# Altium schematic sheet iMX6SL_Power.SchDoc
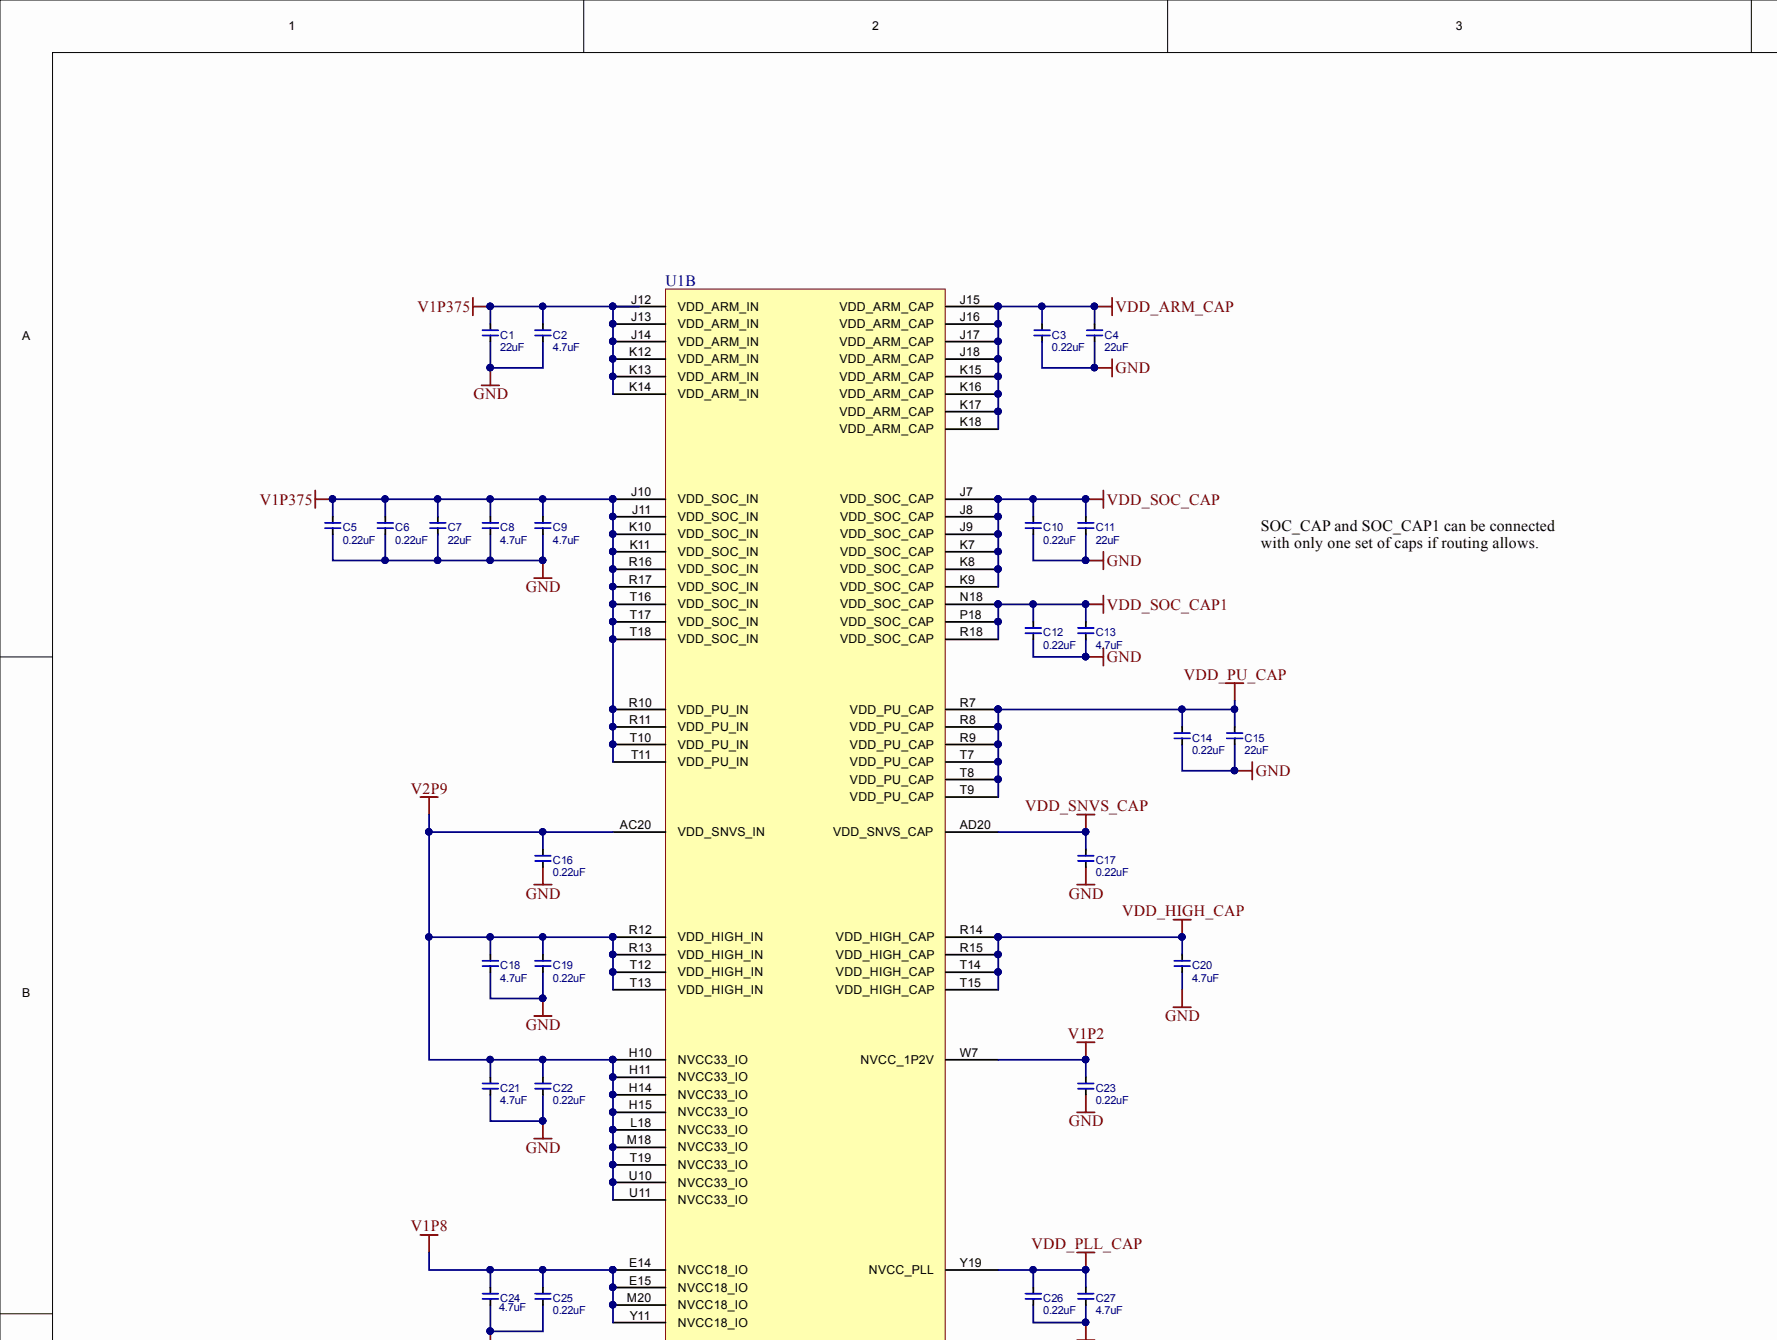
[diagram p0: iMX6SL_Power.SchDoc, top left]
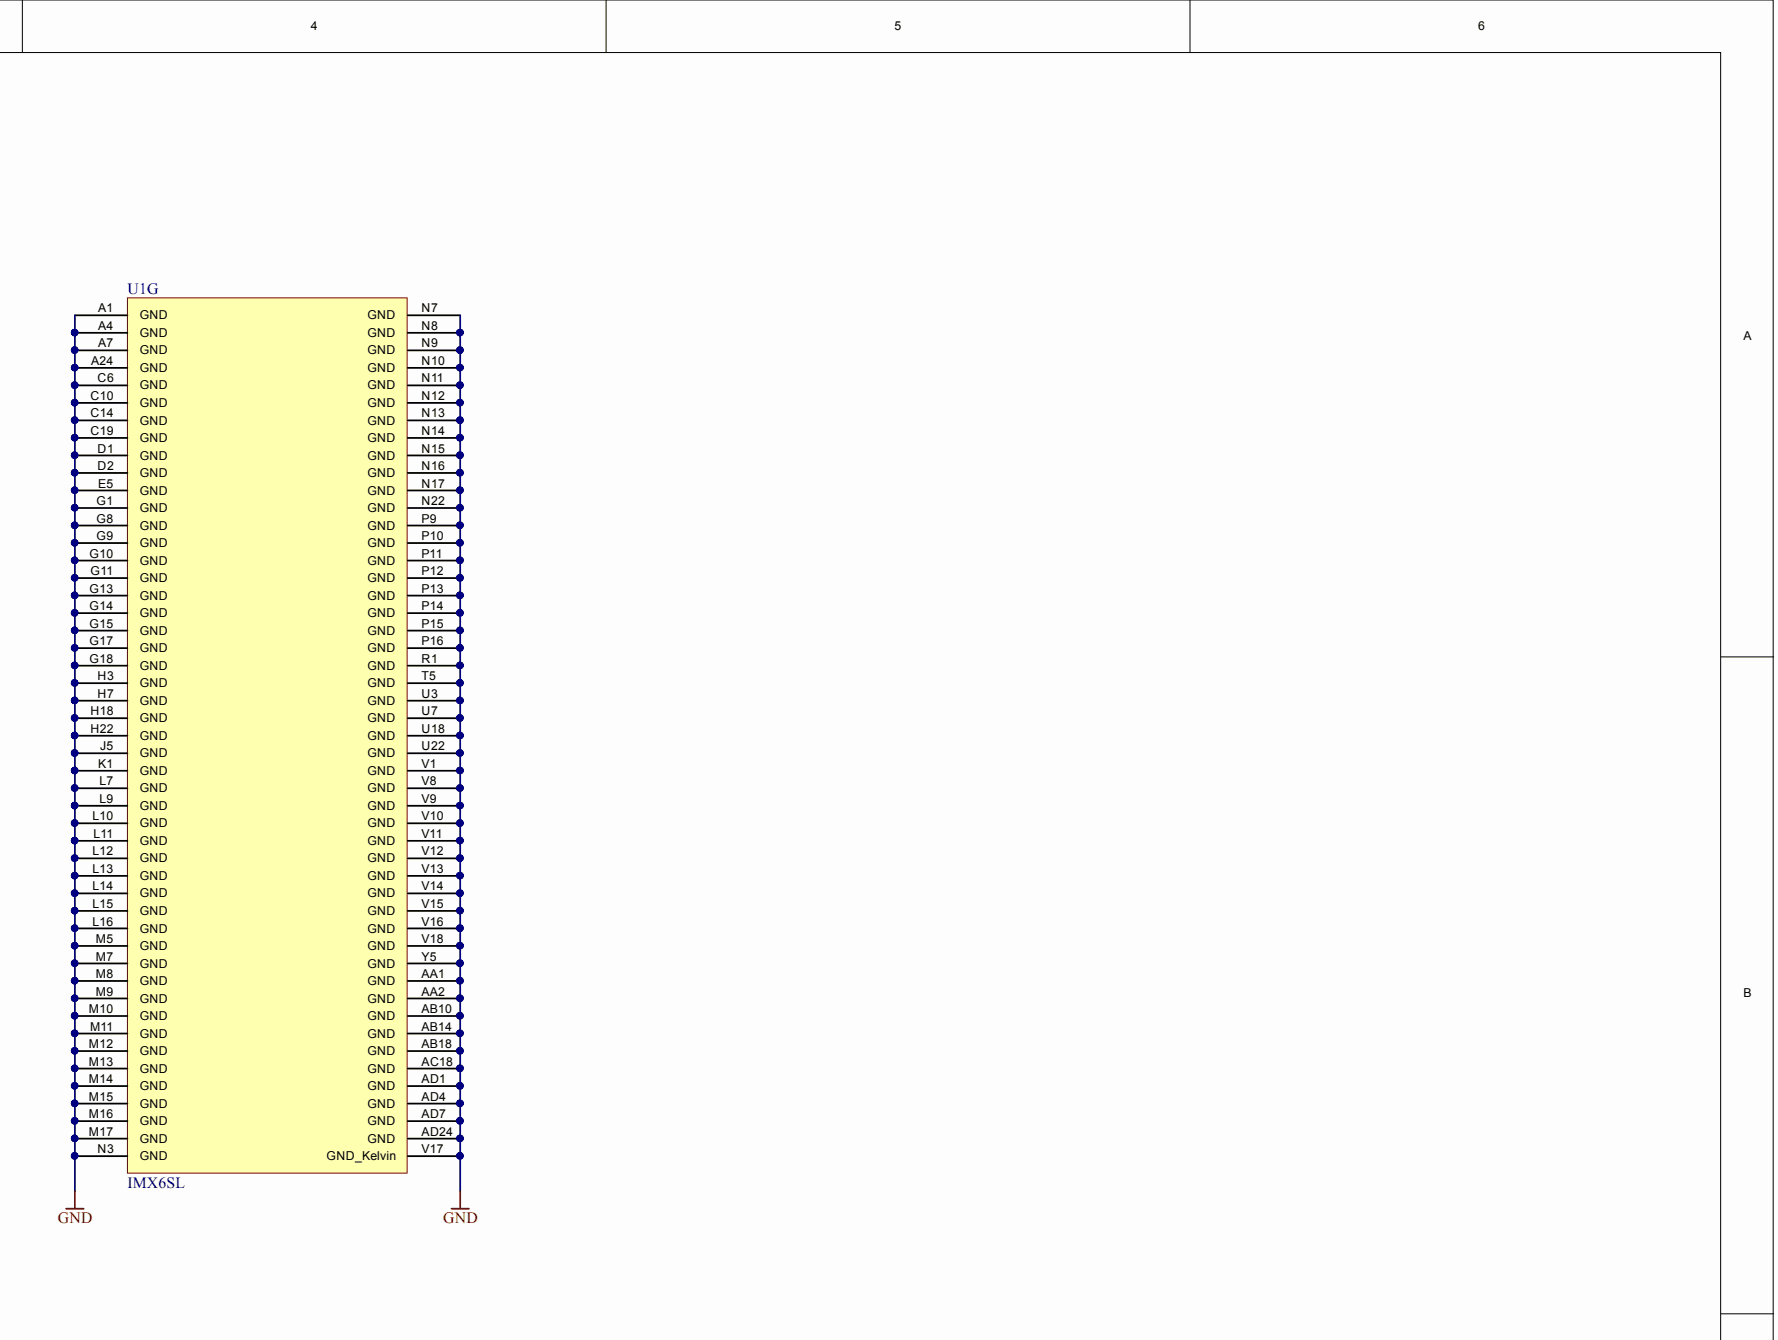
[diagram p1: iMX6SL_Power.SchDoc, top right]
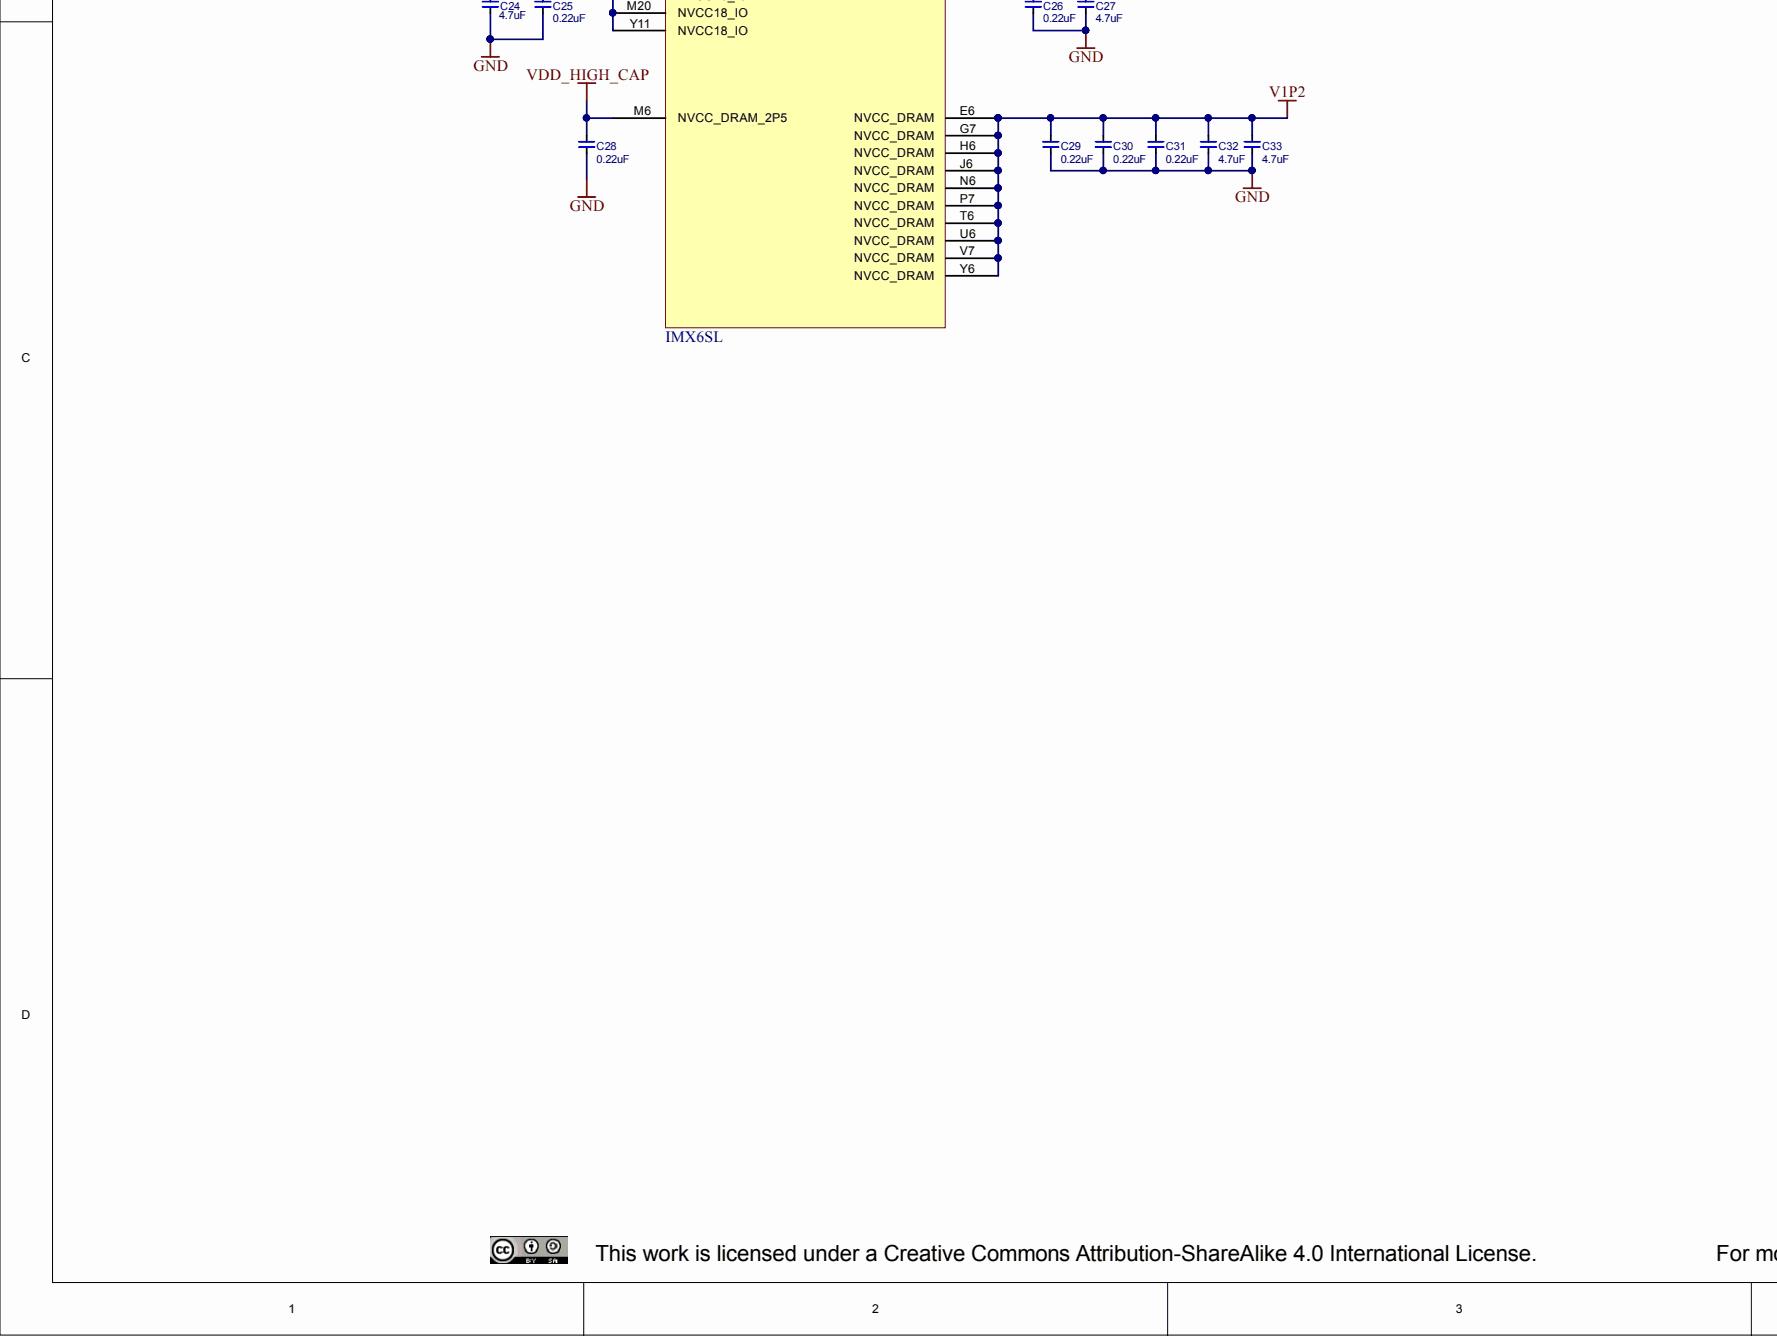
[diagram p2: iMX6SL_Power.SchDoc, bottom left]
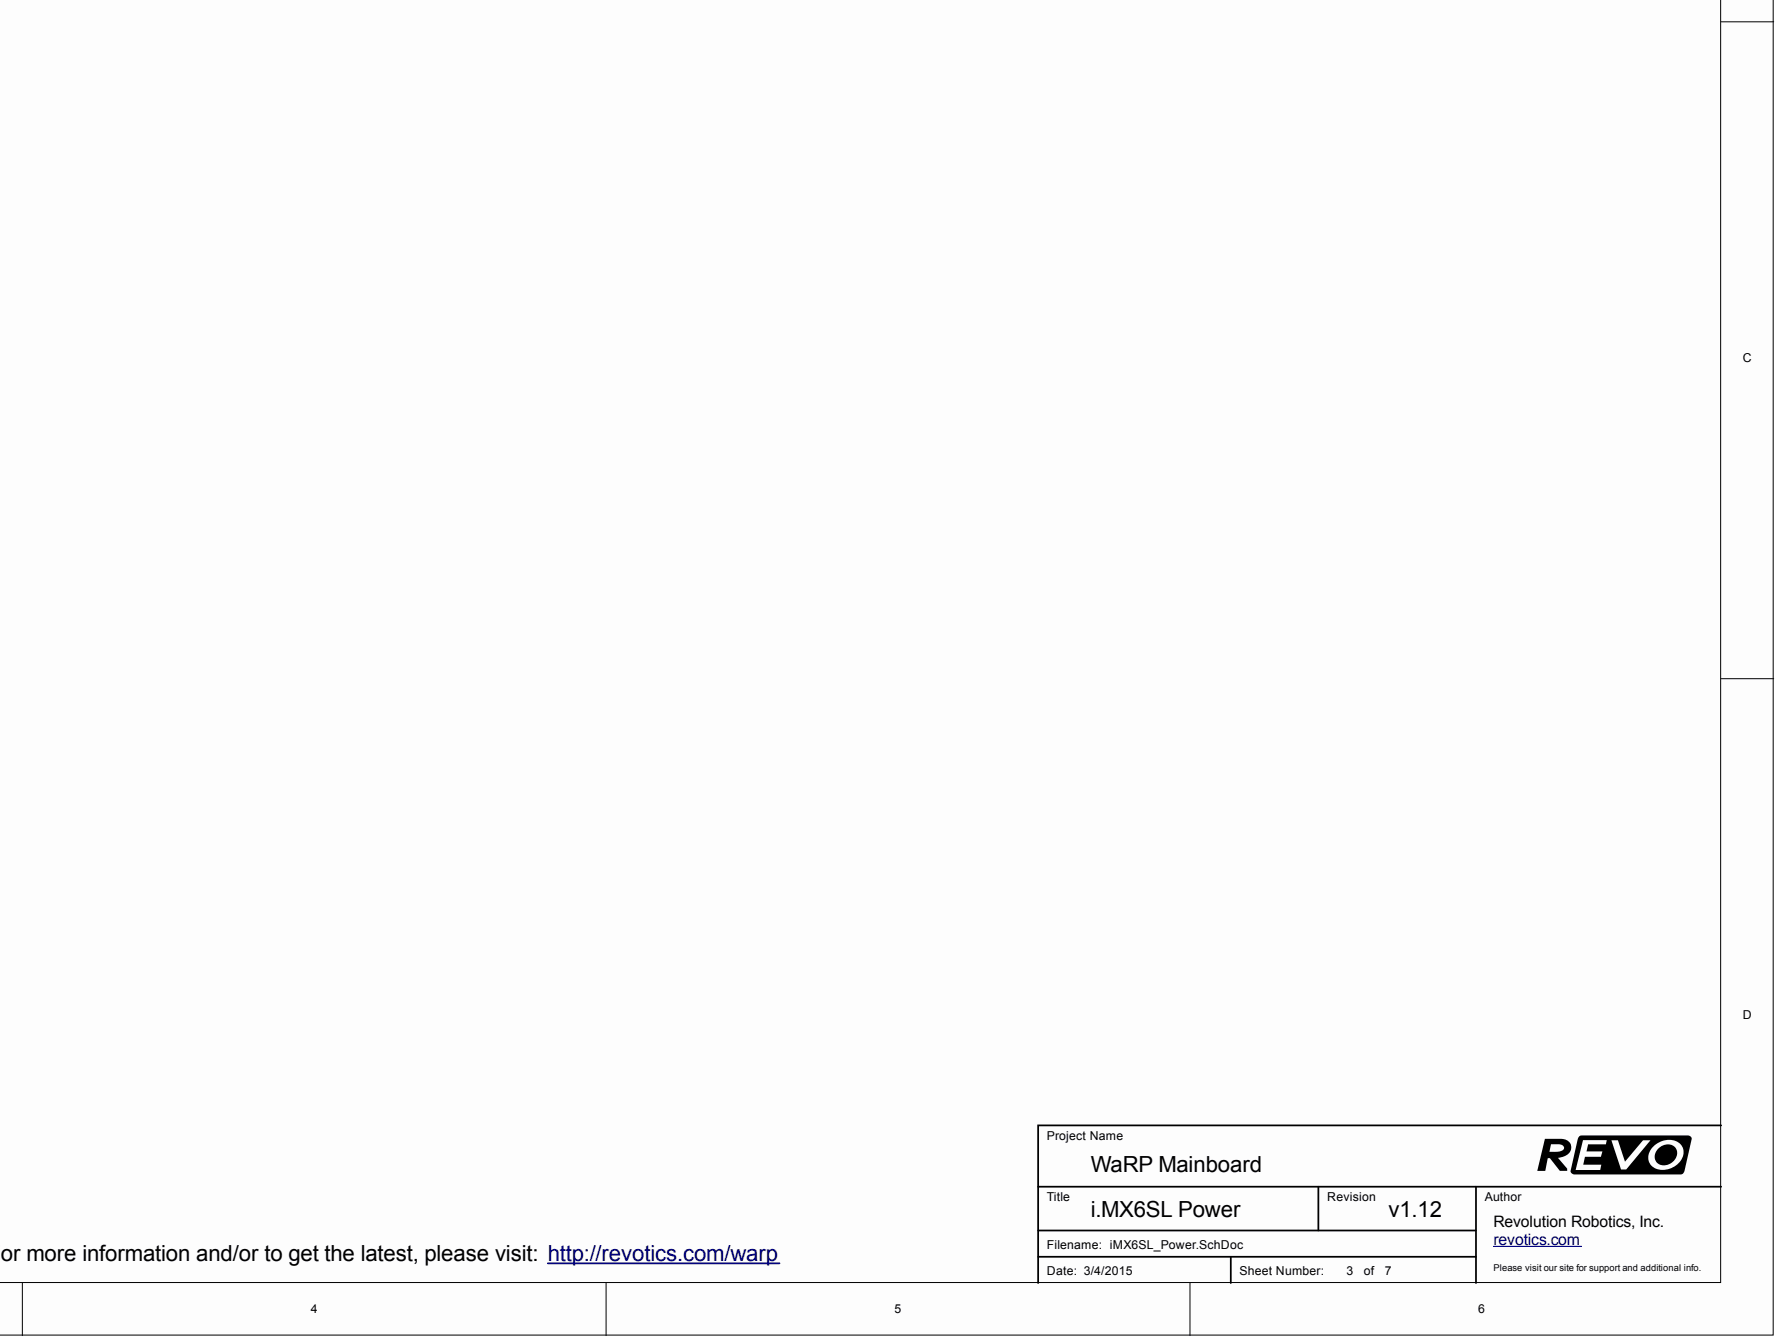
[diagram p3: iMX6SL_Power.SchDoc, bottom right]

## Parts
C1  value 22uF  [0603 (1608) Cap High Density]  (hidden comment: CapacitorSM)
C2  value 4.7uF  [0402 (1005) Cap High Density]  (hidden comment: CapacitorSM)
C3  value 0.22uF  [0201 (0603) Cap High Density]  (hidden comment: CapacitorSM)
C4  value 22uF  [0603 (1608) Cap High Density]  (hidden comment: CapacitorSM)
C5  value 0.22uF  [0201 (0603) Cap High Density]  (hidden comment: CapacitorSM)
C6  value 0.22uF  [0201 (0603) Cap High Density]  (hidden comment: CapacitorSM)
C7  value 22uF  [0603 (1608) Cap High Density]  (hidden comment: CapacitorSM)
C8  value 4.7uF  [0402 (1005) Cap High Density]  (hidden comment: CapacitorSM)
C9  value 4.7uF  [0402 (1005) Cap High Density]  (hidden comment: CapacitorSM)
C10  value 0.22uF  [0201 (0603) Cap High Density]  (hidden comment: CapacitorSM)
C11  value 22uF  [0603 (1608) Cap High Density]  (hidden comment: CapacitorSM)
C12  value 0.22uF  [0201 (0603) Cap High Density]  (hidden comment: CapacitorSM)
C13  value 4.7uF  [0402 (1005) Cap High Density]  (hidden comment: CapacitorSM)
C14  value 0.22uF  [0201 (0603) Cap High Density]  (hidden comment: CapacitorSM)
C15  value 22uF  [0603 (1608) Cap High Density]  (hidden comment: CapacitorSM)
C16  value 0.22uF  [0201 (0603) Cap High Density]  (hidden comment: CapacitorSM)
C17  value 0.22uF  [0201 (0603) Cap High Density]  (hidden comment: CapacitorSM)
C18  value 4.7uF  [0402 (1005) Cap High Density]  (hidden comment: CapacitorSM)
C19  value 0.22uF  [0201 (0603) Cap High Density]  (hidden comment: CapacitorSM)
C20  value 4.7uF  [0402 (1005) Cap High Density]  (hidden comment: CapacitorSM)
C21  value 4.7uF  [0402 (1005) Cap High Density]  (hidden comment: CapacitorSM)
C22  value 0.22uF  [0201 (0603) Cap High Density]  (hidden comment: CapacitorSM)
C23  value 0.22uF  [0201 (0603) Cap High Density]  (hidden comment: CapacitorSM)
C24  value 4.7uF  [0402 (1005) Cap High Density]  (hidden comment: CapacitorSM)
C25  value 0.22uF  [0201 (0603) Cap High Density]  (hidden comment: CapacitorSM)
C26  value 0.22uF  [0201 (0603) Cap High Density]  (hidden comment: CapacitorSM)
C27  value 4.7uF  [0402 (1005) Cap High Density]  (hidden comment: CapacitorSM)
C28  value 0.22uF  [0201 (0603) Cap High Density]  (hidden comment: CapacitorSM)
C29  value 0.22uF  [0201 (0603) Cap High Density]  (hidden comment: CapacitorSM)
C30  value 0.22uF  [0201 (0603) Cap High Density]  (hidden comment: CapacitorSM)
C31  value 0.22uF  [0201 (0603) Cap High Density]  (hidden comment: CapacitorSM)
C32  value 4.7uF  [0402 (1005) Cap High Density]  (hidden comment: CapacitorSM)
C33  value 4.7uF  [0402 (1005) Cap High Density]  (hidden comment: CapacitorSM)
U1  IMX6SL  [BGA432C50P24X24_1300X1300X110]  (parts B,G)

## Nets
GND: C1.2 C2.2 C3.2 C4.2 C5.2 C6.2 C7.2 C8.2 C9.2 C10.2 C11.2 C12.2 C13.2 C14.2 C15.2 C16.2 C17.2 C18.2 C19.2 C20.2 C21.2 C22.2 C23.2 C24.2 C25.2 C26.2 C27.2 C28.2 C29.2 C30.2 C31.2 C32.2 C33.2 U1.A1(GND) U1.A4(GND) U1.A7(GND) U1.A24(GND) U1.AA1(GND) U1.AA2(GND) U1.AB10(GND) U1.AB14(GND) U1.AB18(GND) U1.AC18(GND) U1.AD1(GND) U1.AD4(GND) U1.AD7(GND) U1.AD24(GND) U1.C6(GND) U1.C10(GND) U1.C14(GND) U1.C19(GND) U1.D1(GND) U1.D2(GND) U1.E5(GND) U1.G1(GND) U1.G8(GND) U1.G9(GND) U1.G10(GND) U1.G11(GND) U1.G13(GND) U1.G14(GND) U1.G15(GND) U1.G17(GND) U1.G18(GND) U1.H3(GND) U1.H7(GND) U1.H18(GND) U1.H22(GND) U1.J5(GND) U1.K1(GND) U1.L7(GND) U1.L9(GND) U1.L10(GND) U1.L11(GND) U1.L12(GND) U1.L13(GND) U1.L14(GND) U1.L15(GND) U1.L16(GND) U1.M5(GND) U1.M7(GND) U1.M8(GND) U1.M9(GND) U1.M10(GND) U1.M11(GND) U1.M12(GND) U1.M13(GND) U1.M14(GND) U1.M15(GND) U1.M16(GND) U1.M17(GND) U1.N3(GND) U1.N7(GND) U1.N8(GND) U1.N9(GND) U1.N10(GND) U1.N11(GND) U1.N12(GND) U1.N13(GND) U1.N14(GND) U1.N15(GND) U1.N16(GND) U1.N17(GND) U1.N22(GND) U1.P9(GND) U1.P10(GND) U1.P11(GND) U1.P12(GND) U1.P13(GND) U1.P14(GND) U1.P15(GND) U1.P16(GND) U1.R1(GND) U1.T5(GND) U1.U3(GND) U1.U7(GND) U1.U18(GND) U1.U22(GND) U1.V1(GND) U1.V8(GND) U1.V9(GND) U1.V10(GND) U1.V11(GND) U1.V12(GND) U1.V13(GND) U1.V14(GND) U1.V15(GND) U1.V16(GND) U1.V17(GND_Kelvin) U1.V18(GND) U1.Y5(GND)
V1P2: C23.1 C29.1 C30.1 C31.1 C32.1 C33.1 U1.E6(NVCC_DRAM) U1.G7(NVCC_DRAM) U1.H6(NVCC_DRAM) U1.J6(NVCC_DRAM) U1.N6(NVCC_DRAM) U1.P7(NVCC_DRAM) U1.T6(NVCC_DRAM) U1.U6(NVCC_DRAM) U1.V7(NVCC_DRAM) U1.W7(NVCC_1P2V) U1.Y6(NVCC_DRAM)
V1P8: C24.1 C25.1 U1.E14(NVCC18_IO) U1.E15(NVCC18_IO) U1.M20(NVCC18_IO) U1.Y11(NVCC18_IO)
V1P375: C1.1 C2.1 C5.1 C6.1 C7.1 C8.1 C9.1 U1.J10(VDD_SOC_IN) U1.J11(VDD_SOC_IN) U1.J12(VDD_ARM_IN) U1.J13(VDD_ARM_IN) U1.J14(VDD_ARM_IN) U1.K10(VDD_SOC_IN) U1.K11(VDD_SOC_IN) U1.K12(VDD_ARM_IN) U1.K13(VDD_ARM_IN) U1.K14(VDD_ARM_IN) U1.R10(VDD_PU_IN) U1.R11(VDD_PU_IN) U1.R16(VDD_SOC_IN) U1.R17(VDD_SOC_IN) U1.T10(VDD_PU_IN) U1.T11(VDD_PU_IN) U1.T16(VDD_SOC_IN) U1.T17(VDD_SOC_IN) U1.T18(VDD_SOC_IN)
V2P9: C16.1 C18.1 C19.1 C21.1 C22.1 U1.AC20(VDD_SNVS_IN) U1.H10(NVCC33_IO) U1.H11(NVCC33_IO) U1.H14(NVCC33_IO) U1.H15(NVCC33_IO) U1.L18(NVCC33_IO) U1.M18(NVCC33_IO) U1.R12(VDD_HIGH_IN) U1.R13(VDD_HIGH_IN) U1.T12(VDD_HIGH_IN) U1.T13(VDD_HIGH_IN) U1.T19(NVCC33_IO) U1.U10(NVCC33_IO) U1.U11(NVCC33_IO)
VDD_ARM_CAP: C3.1 C4.1 U1.J15(VDD_ARM_CAP) U1.J16(VDD_ARM_CAP) U1.J17(VDD_ARM_CAP) U1.J18(VDD_ARM_CAP) U1.K15(VDD_ARM_CAP) U1.K16(VDD_ARM_CAP) U1.K17(VDD_ARM_CAP) U1.K18(VDD_ARM_CAP)
VDD_HIGH_CAP: C20.1 C28.1 U1.M6(NVCC_DRAM_2P5) U1.R14(VDD_HIGH_CAP) U1.R15(VDD_HIGH_CAP) U1.T14(VDD_HIGH_CAP) U1.T15(VDD_HIGH_CAP)
VDD_PLL_CAP: C26.1 C27.1 U1.Y19(NVCC_PLL)
VDD_PU_CAP: C14.1 C15.1 U1.R7(VDD_PU_CAP) U1.R8(VDD_PU_CAP) U1.R9(VDD_PU_CAP) U1.T7(VDD_PU_CAP) U1.T8(VDD_PU_CAP) U1.T9(VDD_PU_CAP)
VDD_SNVS_CAP: C17.1 U1.AD20(VDD_SNVS_CAP)
VDD_SOC_CAP: C10.1 C11.1 U1.J7(VDD_SOC_CAP) U1.J8(VDD_SOC_CAP) U1.J9(VDD_SOC_CAP) U1.K7(VDD_SOC_CAP) U1.K8(VDD_SOC_CAP) U1.K9(VDD_SOC_CAP)
VDD_SOC_CAP1: C12.1 C13.1 U1.N18(VDD_SOC_CAP) U1.P18(VDD_SOC_CAP) U1.R18(VDD_SOC_CAP)

## Library data of the parts
C1 C4 C7 C11 C15 = CapacitorSM: Capacitor; Manufacturer: Murata; Part No.: GRM188C80G226MEA0D; Vendor: Mouser; Component Kind: Standard; Library Name: Passive.SchLib
C2 C8 C9 C13 C18 C20 C21 C24 C27 C32 C33 = CapacitorSM: Capacitor; Manufacturer: TDK; Part No.: C1005X5R0J475M050BC; Vendor: Digikey; Voltage: 6.3; Component Kind: Standard; Library Name: Passive.SchLib
C3 C5 C6 C10 C12 C14 C16 C17 C19 C22 C23 C25 C26 C28-C31 = CapacitorSM: Capacitor; Manufacturer: TDK; Part No.: C0603X5R0J224K030BB; Vendor: Digikey; Voltage: 6.3; Component Kind: Standard; Library Name: Passive.SchLib
U1 = IMX6SL: Freescale iMX6 SoloLite; Part No.: MCIMX6L8DVN10AB; Vendor: Verical; Component Kind: Standard; ComponentLink1Description: Datasheet; ComponentLink2Description: Freescale Webpage; ComponentLink2URL: http://www.freescale.com/webapp/sps/site/prod_summary.jsp?code=i.MX6SL; Library Name: iMX6.SchLib; Manufacturer: Freescale; Supplier 1: Newark; Supplier Part Number 1: 31W8709; ComponentLink1URL: R:\Hardware\Part Datasheets\Freescale\IMX6SLCEC.pdf
